# Altium schematic sheet ESP+Power Amplifier.SchDoc
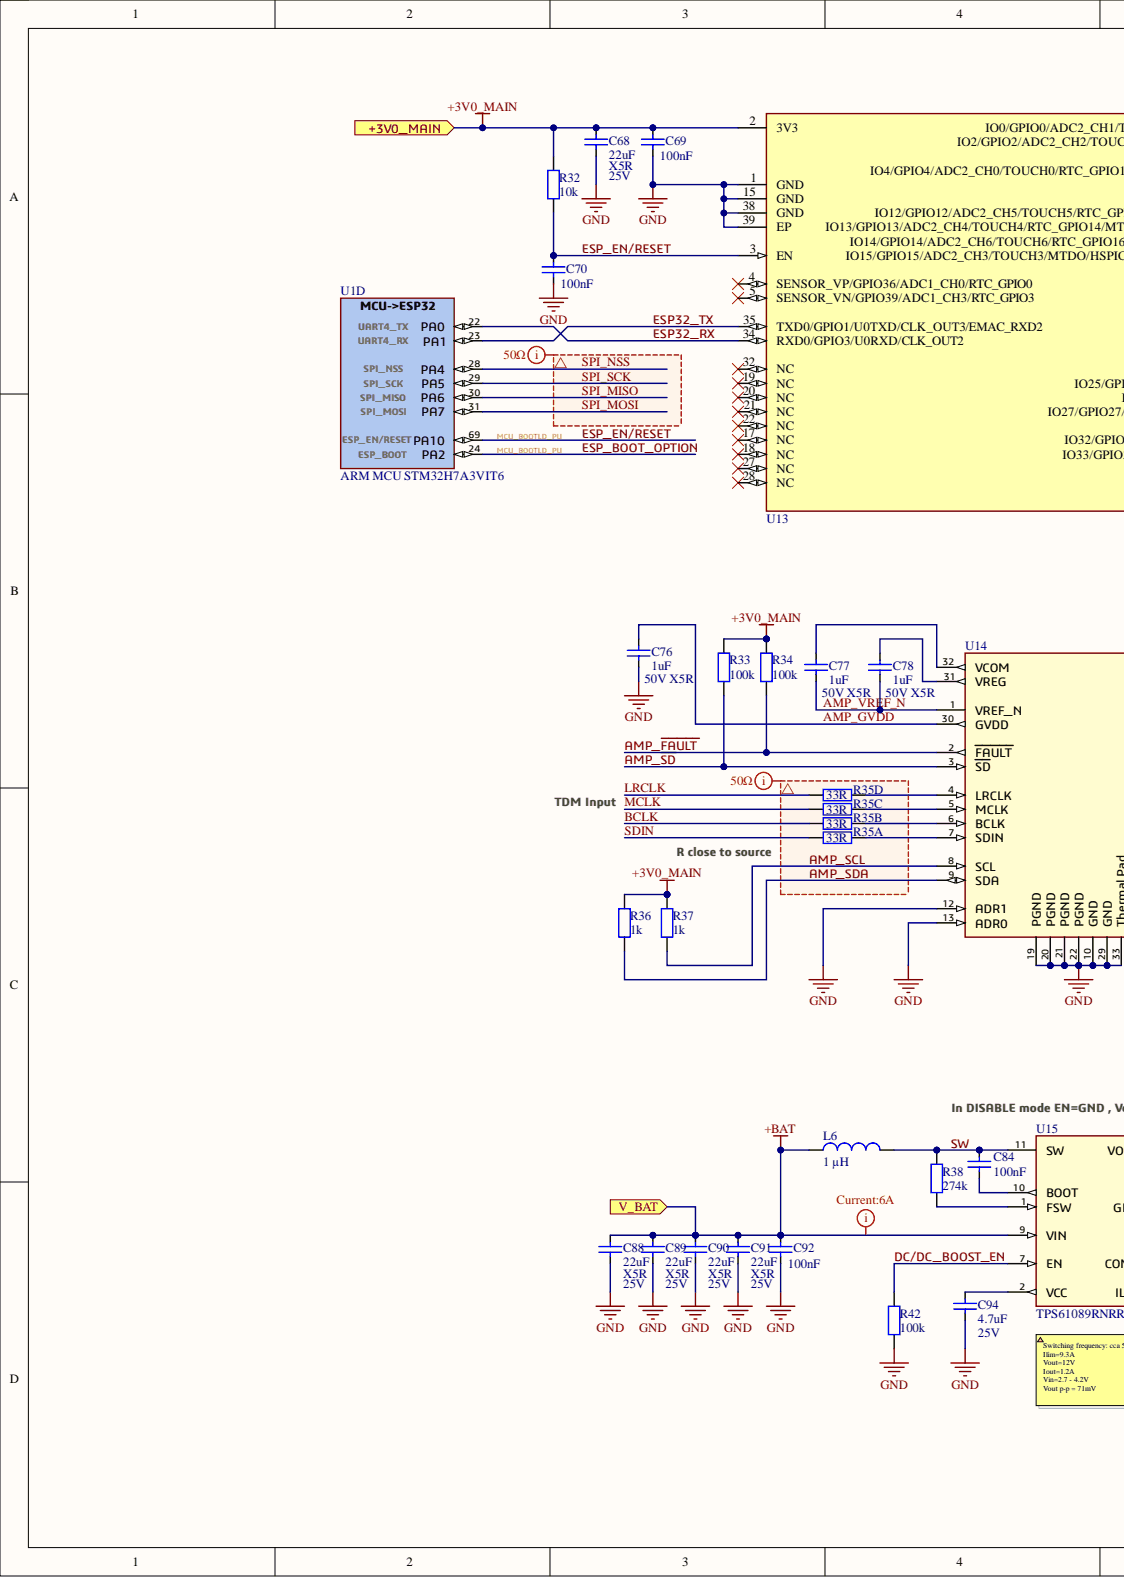
[diagram p0: ESP+Power Amplifier.SchDoc, left]
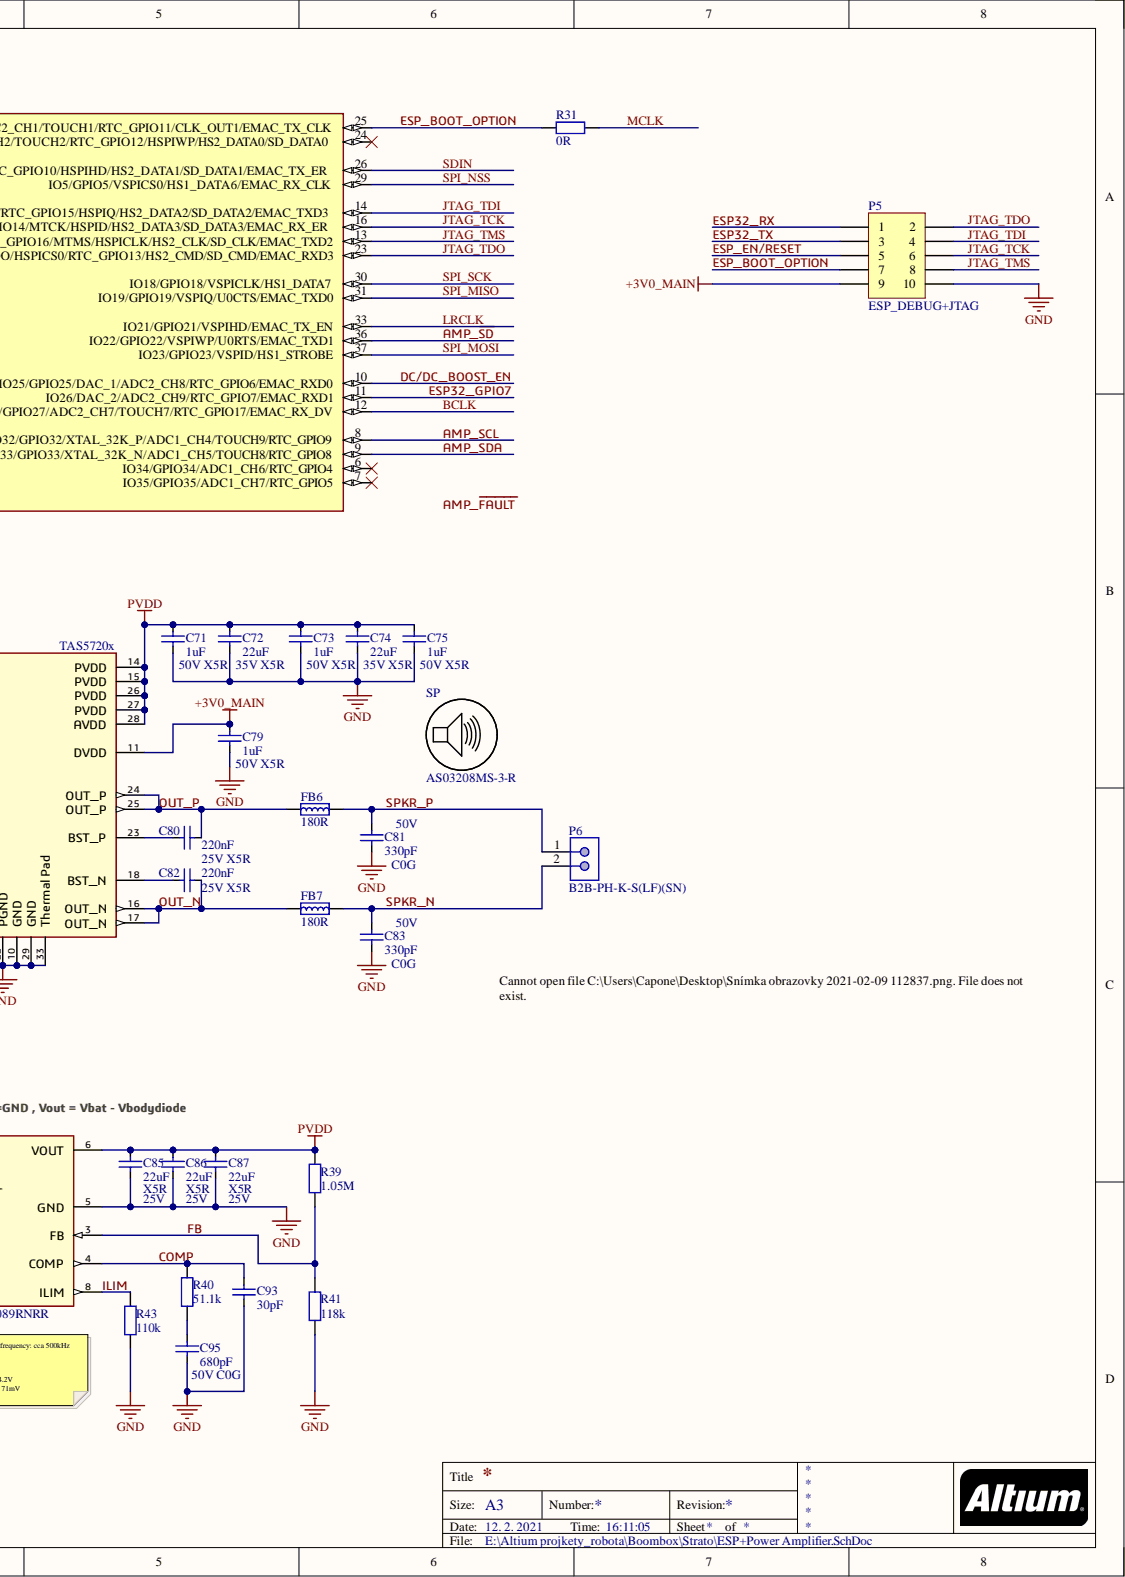
[diagram p1: ESP+Power Amplifier.SchDoc, right]

## Parts
C68  22uF  Dielectric: X5R  Voltage: 25V  [CAPACITOR_0805_(2012)]
C69  100nF  [CAPACITOR_0402_(1005)]
C70  100nF  [CAPACITOR_0402_(1005)]
C71  1uF  Voltage Rating (DC): 50V  [CAPACITOR_0603_(1608)]
C72  22uF  Voltage Rating: 35V X5R  Voltage Rating (DC): 35V  [CAPACITOR_1206_(3216)]
C73  1uF  Dielectric: X5R  Voltage Rating: 50V X5R  Voltage Rating (DC): 50V  [CAPACITOR_0603_(1608)]
C74  22uF  Voltage Rating: 35V X5R  Voltage Rating (DC): 35V  [CAPACITOR_1206_(3216)]
C75  1uF  Dielectric: X5R  Voltage Rating: 50V X5R  Voltage Rating (DC): 50V  [CAPACITOR_0603_(1608)]
C76  1uF  Dielectric: X5R  Voltage Rating: 50V X5R  Voltage Rating (DC): 50V  [CAPACITOR_0603_(1608)]
C77  1uF  Voltage Rating (DC): 50V  [CAPACITOR_0603_(1608)]
C78  1uF  Dielectric: X5R  Voltage Rating: 50V X5R  Voltage Rating (DC): 50V  [CAPACITOR_0603_(1608)]
C79  1uF  Dielectric: X5R  Voltage Rating: 50V X5R  Voltage Rating (DC): 50V  [CAPACITOR_0603_(1608)]
C80  220nF  [CAPACITOR_0603_(1608)]
C81  330pF  Dielectric: C0G  Voltage Rating: 50V  [CAPACITOR_0603_(1608)]
C82  220nF  Voltage Rating: 25V X5R  [CAPACITOR_0603_(1608)]
C83  330pF  Dielectric: C0G  Voltage Rating: 50V  [CAPACITOR_0603_(1608)]
C84  100nF  [CAPACITOR_0402_(1005)]
C85  22uF  Dielectric: X5R  Voltage: 25V  [CAPACITOR_0805_(2012)]
C86  22uF  Dielectric: X5R  Voltage: 25V  [CAPACITOR_0805_(2012)]
C87  22uF  Dielectric: X5R  Voltage: 25V  [CAPACITOR_0805_(2012)]
C88  22uF  Dielectric: X5R  Voltage: 25V  [CAPACITOR_0805_(2012)]
C89  22uF  Dielectric: X5R  Voltage: 25V  [CAPACITOR_0805_(2012)]
C90  22uF  Dielectric: X5R  Voltage: 25V  [CAPACITOR_0805_(2012)]
C91  22uF  Dielectric: X5R  Voltage: 25V  [CAPACITOR_0805_(2012)]
C92  100nF  [CAPACITOR_0402_(1005)]
C93  30pF  [CAPACITOR_0603_(1608)]
C94  4.7uF  Voltage: 25V  [CAPACITOR_0603_(1608)]
C95  680pF  Dielectric: C0G  Voltage: 50V  Voltage Rating: 50V C0G  [CAPACITOR_0603_(1608)]
FB6  180R  [FERRITE BEAD_0806 (2016 metric)]
FB7  180R  [FERRITE BEAD_0806 (2016 metric)]
L6  1 µH  [Power Inductor_073x066 (SMD)]
P5  ESP_DEBUG+JTAG  [FTE-105-XX-X-DV]  (no BOM)
P6  B2B-PH-K-S(LF)(SN)  [JST-B2B-PH-K-S]
R31  0R  [RESISTOR_0603_(1608)]
R32  10k  [RESISTOR_0603_(1608)]
R33  100k  [RESISTOR_0603_(1608)]
R34  100k  [RESISTOR_0603_(1608)]
R35  33R  [RESISTOR_NETWORK_4x_0603_(1608)]  (parts A,B,C,D)
R36  1k  [RESISTOR_0603_(1608)]
R37  1k  [RESISTOR_0603_(1608)]
R38  274k  [RESISTOR_0603_(1608)]
R39  1.05M  [RESISTOR_0603_(1608)]
R40  51.1k  [RESISTOR_0603_(1608)]
R41  118k  [RESISTOR_0603_(1608)]
R42  100k  [RESISTOR_0603_(1608)]
R43  110k  [RESISTOR_0603_(1608)]
SP  AS03208MS-3-R  [Speaker AS03208MS-3-R]
U1  ARM MCU STM32H7A3VIT6  Manufacturer Part Number: STM32H7A3VIT6  [STM-LQFP100_N - Edited]  (part D)
U13  ESP32-WROVER-E 64Mbit PSRAM, 16 MB SPI Flash  [ESP32-WROVER-E]  (value not drawn)
U14  TAS5720x  [VQFN32 4 x 4, 0.4 mm p]
U15  TPS61089RNRR  [VQFN_HR RNR0011A]

## Ports
+3V0_MAIN, V_BAT

## Nets
GND: C68.1 C69.2 C70.2 C71.2 C72.2 C73.2 C74.2 C75.2 C76.2 C79.2 C81.1 C83.1 C85.1 C86.1 C87.1 C88.1 C89.1 C90.1 C91.1 C92.2 C93.1 C94.2 C95.1 P5.10 R41.1 R42.1 R43.1 U13.1(GND) U13.15(GND) U13.38(GND) U13.39(EP) U14.10(GND) U14.12(ADR1) U14.13(ADR0) U14.19(PGND) U14.20(PGND) U14.21(PGND) U14.22(PGND) U14.29(GND) U14.33(Thermal_Pad) U15.5(GND)
PVDD: C71.1 C72.1 C73.1 C74.1 C75.1 C85.2 C86.2 C87.2 R39.2 U14.14(PVDD) U14.15(PVDD) U14.26(PVDD) U14.27(PVDD) U14.28(AVDD) U15.6(VOUT)
+3V0_MAIN: C68.2 C69.1 C79.1 P5.9 R32.1 R33.2 R34.2 R36.2 R37.2 U13.2(3V3) U14.11(DVDD) ; port +3V0_MAIN
+BAT: C88.2 C89.2 C90.2 C91.2 C92.1 L6.1 U15.9(VIN) ; port V_BAT
AMP_~{FAULT}: R34.1 U14.2(FAULT)
AMP_GVDD: C76.1 U14.30(GVDD)
AMP_~{SD}: R33.1 U13.36(IO22/GPIO22/VSPIWP/U0RTS/EMAC_TXD1) U14.3(SD)
AMP_SCL: R37.1 U13.8(IO32/GPIO32/XTAL_32K_P/ADC1_CH4/TOUCH9/RTC_GPIO9) U14.8(SCL)
AMP_SDA: R36.1 U13.9(IO33/GPIO33/XTAL_32K_N/ADC1_CH5/TOUCH8/RTC_GPIO8) U14.9(SDA)
AMP_VREF_N: C77.2 C78.2 U14.1(VREF_N)
BCLK: R35.4 U13.12(IO27/GPIO27/ADC2_CH7/TOUCH7/RTC_GPIO17/EMAC_RX_DV)
COMP: C93.2 R40.2 U15.4(COMP)
DC/DC_BOOST_EN: R42.2 U13.10(IO25/GPIO25/DAC_1/ADC2_CH8/RTC_GPIO6/EMAC_RXD0) U15.7(EN)
ESP32_GPIO7: U13.11(IO26/DAC_2/ADC2_CH9/RTC_GPIO7/EMAC_RXD1)
ESP32_RX: P5.1 U1.22(PA0) U13.34(RXD0/GPIO3/U0RXD/CLK_OUT2)
ESP32_TX: P5.3 U1.23(PA1) U13.35(TXD0/GPIO1/U0TXD/CLK_OUT3/EMAC_RXD2)
ESP_BOOT_OPTION: P5.7 R31.2 U1.24(PA2) U13.25(IO0/GPIO0/ADC2_CH1/TOUCH1/RTC_GPIO11/CLK_OUT1/EMAC_TX_CLK)
ESP_EN/RESET: C70.1 P5.5 R32.2 U1.69(PA10) U13.3(EN)
FB: R39.1 R41.2 U15.3(FB)
ILIM: R43.2 U15.8(ILIM)
JTAG_TCK: P5.6 U13.16(IO13/GPIO13/ADC2_CH4/TOUCH4/RTC_GPIO14/MTCK/HSPID/HS2_DATA3/SD_DATA3/EMAC_RX_ER)
JTAG_TDI: P5.4 U13.14(IO12/GPIO12/ADC2_CH5/TOUCH5/RTC_GPIO15/HSPIQ/HS2_DATA2/SD_DATA2/EMAC_TXD3)
JTAG_TDO: P5.2 U13.23(IO15/GPIO15/ADC2_CH3/TOUCH3/MTDO/HSPICS0/RTC_GPIO13/HS2_CMD/SD_CMD/EMAC_RXD3)
JTAG_TMS: P5.8 U13.13(IO14/GPIO14/ADC2_CH6/TOUCH6/RTC_GPIO16/MTMS/HSPICLK/HS2_CLK/SD_CLK/EMAC_TXD2)
LRCLK: R35.8 U13.33(IO21/GPIO21/VSPIHD/EMAC_TX_EN)
MCLK: R31.1 R35.6
OUT_N: C82.2 FB7.1 U14.16(OUT_N) U14.17(OUT_N)
OUT_P: C80.2 FB6.1 U14.24(OUT_P) U14.25(OUT_P)
SDIN: R35.2 U13.26(IO4/GPIO4/ADC2_CH0/TOUCH0/RTC_GPIO10/HSPIHD/HS2_DATA1/SD_DATA1/EMAC_TX_ER)
SPI_MISO: U1.30(PA6) U13.31(IO19/GPIO19/VSPIQ/U0CTS/EMAC_TXD0)
SPI_MOSI: U1.31(PA7) U13.37(IO23/GPIO23/VSPID/HS1_STROBE)
SPI_NSS: U1.28(PA4) U13.29(IO5/GPIO5/VSPICS0/HS1_DATA6/EMAC_RX_CLK)
SPI_SCK: U1.29(PA5) U13.30(IO18/GPIO18/VSPICLK/HS1_DATA7)
SPKR_N: C83.2 FB7.2 P6.2
SPKR_P: C81.2 FB6.2 P6.1
SW: C84.2 L6.2 R38.2 U15.11(SW)
NetC77_1: C77.1 U14.32(VCOM)
NetC78_1: C78.1 U14.31(VREG)
NetC80_1: C80.1 U14.23(BST_P)
NetC82_1: C82.1 U14.18(BST_N)
NetC84_1: C84.1 U15.10(BOOT)
NetC94_1: C94.1 U15.2(VCC)
NetC95_2: C95.2 R40.1
NetR35_1: R35.1 U14.7(SDIN)
NetR35_3: R35.3 U14.6(BCLK)
NetR35_5: R35.5 U14.5(MCLK)
NetR35_7: R35.7 U14.4(LRCLK)
NetR38_1: R38.1 U15.1(FSW)

Not connected: U13 4(SENSOR_VP/GPIO36/ADC1_CH0/RTC_GPIO0) 5(SENSOR_VN/GPIO39/ADC1_CH3/RTC_GPIO3) 6(IO34/GPIO34/ADC1_CH6/RTC_GPIO4) 7(IO35/GPIO35/ADC1_CH7/RTC_GPIO5) 17(NC) 18(NC) 19(NC) 20(NC) 21(NC) 22(NC) 24(IO2/GPIO2/ADC2_CH2/TOUCH2/RTC_GPIO12/HSPIWP/HS2_DATA0/SD_DATA0) 27(NC) 28(NC) 32(NC)

## Library data of the parts
C68 C85-C91 = CAPACITOR: Manufacturer: Murata; Manufacturer Part Number 1: GRM21BR61E226ME44L; Material: Ceramic; Tolerance: 20%; Capacitance: 22uF; Case Code (Imperial): 0805; Case Code (Metric): 2012; Case/Package: 0805; Component Kind: Standard; ComponentLink1Description: https://datasheet.ciiva.com/22787/1747471-22787265.pdf?src-supplier=Farnell; ComponentLink1URL: https://datasheet.ciiva.com/22787/1747471-22787265.pdf?src-supplier=Farnell; ComponentLink2Description: https://datasheet.ciiva.com/7719/1747471-7719095.pdf?src-supplier=Element14; ComponentLink2URL: https://datasheet.ciiva.com/7719/1747471-7719095.pdf?src-supplier=Element14; ComponentLink3Description: https://datasheet.ciiva.com/28584/1747471-28584199.pdf?src-supplier=Element14; ComponentLink3URL: https://datasheet.ciiva.com/28584/1747471-28584199.pdf?src-supplier=Element14; Composition: Ceramic; Depth: 1.25mm; Description: CAPACITOR; Height: 1.25mm; Lead Free: Lead Free; Length: 2mm; Max Operating Temperature: 85°C; Min Operating Temperature: -55°C; Mount: Surface Mount; Package Quantity: 3000; Packaging: Tape and Reel; Pricing 1: 5=0,42800, 50=0,25100, 250=0,20300, 500=0,15000, 1500=0,12800 (EUR); REACH SVHC: No SVHC; RoHS: Yes; Stock 1: 70400; Supplier 1: Farnell; Supplier Part Number 1: 1907510; Termination: SMD/SMT; Thickness: 0.057inch; Voltage Rating: 25V; Voltage Rating (DC): 25V; Weight: 0.000335oz; Width: 1.25mm; Library Name: RLCFB.SchLib
C69 C70 C84 C92 C93 = CAPACITOR: ESR: 0.28R; Voltage Rating: 10V; Component Kind: Standard; Description: CAPACITOR; Library Name: RLCFB.SchLib
  C69 C70 C84 C92: Capacitance: 100nF
  C93: Capacitance: 30pF
C71 C77 = CAPACITOR: Manufacturer: Taiyo Yuden; Manufacturer Part Number 1: UMK107BJ105KA-T; Dielectric: X5R; ESR: 0.28R; Tolerance: 10%; Voltage Rating: 50V X5R; Capacitance: 1uF; Case Code (Imperial): 0603; Case Code (Metric): 1608; Case/Package: 0603; Component Kind: Standard; ComponentLink1Description: https://datasheet.ciiva.com/5744/1697715-5744913.pdf?src-supplier=Farnell; ComponentLink1URL: https://datasheet.ciiva.com/5744/1697715-5744913.pdf?src-supplier=Farnell; ComponentLink2Description: https://datasheet.ciiva.com/11601/umk107bj105ka-t-ss-11601811.pdf?src-supplier=Avnet; ComponentLink2URL: https://datasheet.ciiva.com/11601/umk107bj105ka-t-ss-11601811.pdf?src-supplier=Avnet; ComponentLink3Description: https://datasheet.ciiva.com/15922/336umk107bj105ka-t-15922611.pdf?src-supplier=Onlinecomponents.com; ComponentLink3URL: https://datasheet.ciiva.com/15922/336umk107bj105ka-t-15922611.pdf?src-supplier=Onlinecomponents.com; ComponentLink4Description: https://datasheet.ciiva.com/134/umk107bj105ka-t-ss-134740.pdf?src-supplier=Digi-Key; ComponentLink4URL: https://datasheet.ciiva.com/134/umk107bj105ka-t-ss-134740.pdf?src-supplier=Digi-Key; ComponentLink5Description: https://datasheet.ciiva.com/30199/43umk107bj105ka-t-30199791.pdf?src-supplier=Onlinecomponents.com; ComponentLink5URL: https://datasheet.ciiva.com/30199/43umk107bj105ka-t-30199791.pdf?src-supplier=Onlinecomponents.com; ComponentLink6Description: https://datasheet.ciiva.com/22694/1697715-22694278.pdf?src-supplier=Element14; ComponentLink6URL: https://datasheet.ciiva.com/22694/1697715-22694278.pdf?src-supplier=Element14; ComponentLink7Description: https://datasheet.ciiva.com/7975/1697715-7975608.pdf?src-supplier=Element14; ComponentLink7URL: https://datasheet.ciiva.com/7975/1697715-7975608.pdf?src-supplier=Element14; ComponentLink8Description: https://datasheet.ciiva.com/7975/mlcc-all-e-7975898.pdf?src-supplier=Element14; ComponentLink8URL: https://datasheet.ciiva.com/7975/mlcc-all-e-7975898.pdf?src-supplier=Element14; ComponentLink9Description: https://datasheet.ciiva.com/5427/mlcc-all-e-541559-5427036.pdf?src-supplier=Mouser; ComponentLink9URL: https://datasheet.ciiva.com/5427/mlcc-all-e-541559-5427036.pdf?src-supplier=Mouser; Contact Plating: Nickel; Depth: 0.8mm; Description: CAPACITOR; Halogen Free: Halogen Free; Height: 0.8mm; Length: 1.6mm; Max Operating Temperature: 85°C; Min Operating Temperature: -55°C; Mount: Surface Mount; Number of Pins: 2; Package Quantity: 1; Packaging: Tape and Reel; Pricing 1: 10=0,14300, 100=0,06560, 500=0,04260, 1000=0,03940, 2000=0,03750, 4000=0,02830, 20000=0,02630 (EUR); Radiation Hardening: No; REACH SVHC: Unknown; RoHS: Yes; Stock 1: 372983; Supplier 1: Farnell; Supplier Part Number 1: 2112858; Termination: SMD/SMT; Thickness: 0.035inch; Width: 0.031inch; Library Name: RLCFB.SchLib
C72 C74 = CAPACITOR: Manufacturer: TDK; Manufacturer Part Number 1: C3216JB1V226M160AC; Dielectric Material: Ceramic; ESR: 0.28R; Tolerance: 20%; Capacitance: 22uF; Case Code (Imperial): 1206; Case Code (Metric): 3216; Case/Package: 1206; Component Kind: Standard; ComponentLink1Description: http://www.mouser.com/ds/2/400/lcc_commercial_general_en-837201.pdf?src-supplier=Mouser; ComponentLink1URL: http://www.mouser.com/ds/2/400/lcc_commercial_general_en-837201.pdf?src-supplier=Mouser; ComponentLink2Description: https://product.tdk.com/info/en/catalog/spec/mlccspec_commercial_general_midvoltage_en.pdf?src-supplier=Digi-Key; ComponentLink2URL: https://product.tdk.com/info/en/catalog/spec/mlccspec_commercial_general_midvoltage_en.pdf?src-supplier=Digi-Key; ComponentLink3Description: http://product.tdk.com/en/catalog/datasheets/mlcc_commercial_general_en.pdf?src-supplier=Avnet; ComponentLink3URL: http://product.tdk.com/en/catalog/datasheets/mlcc_commercial_general_en.pdf?src-supplier=Avnet; Composition: Ceramic; Description: CAPACITOR; Height: 1.6mm; Lead Free: Lead Free; Length: 0.126inch; Max Operating Temperature: 85°C; Min Operating Temperature: -25°C; Mount: Surface Mount; Package Quantity: 2000; Packaging: Tape and Reel; Pricing 1: 1=1,12000, 10=0,84700, 50=0,84700, 100=0,59600, 1000=0,45200, 10000=0,28700 (USD); RoHS: Yes; Stock 1: 128007; Supplier 1: Mouser; Supplier Part Number 1: 810-C3216JB1V226M16C; Termination: SMD/SMT; Thickness: 0.069inch; Width: 0.063inch; Library Name: RLCFB.SchLib
C73 C75 C76 C78 C79 = CAPACITOR: Manufacturer: Taiyo Yuden; Manufacturer Part Number 1: UMK107BJ105KA-T; ESR: 0.28R; Tolerance: 10%; Capacitance: 1uF; Case Code (Imperial): 0603; Case Code (Metric): 1608; Case/Package: 0603; Component Kind: Standard; ComponentLink1Description: https://datasheet.ciiva.com/5744/1697715-5744913.pdf?src-supplier=Farnell; ComponentLink1URL: https://datasheet.ciiva.com/5744/1697715-5744913.pdf?src-supplier=Farnell; ComponentLink2Description: https://datasheet.ciiva.com/11601/umk107bj105ka-t-ss-11601811.pdf?src-supplier=Avnet; ComponentLink2URL: https://datasheet.ciiva.com/11601/umk107bj105ka-t-ss-11601811.pdf?src-supplier=Avnet; ComponentLink3Description: https://datasheet.ciiva.com/15922/336umk107bj105ka-t-15922611.pdf?src-supplier=Onlinecomponents.com; ComponentLink3URL: https://datasheet.ciiva.com/15922/336umk107bj105ka-t-15922611.pdf?src-supplier=Onlinecomponents.com; ComponentLink4Description: https://datasheet.ciiva.com/134/umk107bj105ka-t-ss-134740.pdf?src-supplier=Digi-Key; ComponentLink4URL: https://datasheet.ciiva.com/134/umk107bj105ka-t-ss-134740.pdf?src-supplier=Digi-Key; ComponentLink5Description: https://datasheet.ciiva.com/30199/43umk107bj105ka-t-30199791.pdf?src-supplier=Onlinecomponents.com; ComponentLink5URL: https://datasheet.ciiva.com/30199/43umk107bj105ka-t-30199791.pdf?src-supplier=Onlinecomponents.com; ComponentLink6Description: https://datasheet.ciiva.com/22694/1697715-22694278.pdf?src-supplier=Element14; ComponentLink6URL: https://datasheet.ciiva.com/22694/1697715-22694278.pdf?src-supplier=Element14; ComponentLink7Description: https://datasheet.ciiva.com/7975/1697715-7975608.pdf?src-supplier=Element14; ComponentLink7URL: https://datasheet.ciiva.com/7975/1697715-7975608.pdf?src-supplier=Element14; ComponentLink8Description: https://datasheet.ciiva.com/7975/mlcc-all-e-7975898.pdf?src-supplier=Element14; ComponentLink8URL: https://datasheet.ciiva.com/7975/mlcc-all-e-7975898.pdf?src-supplier=Element14; ComponentLink9Description: https://datasheet.ciiva.com/5427/mlcc-all-e-541559-5427036.pdf?src-supplier=Mouser; ComponentLink9URL: https://datasheet.ciiva.com/5427/mlcc-all-e-541559-5427036.pdf?src-supplier=Mouser; Contact Plating: Nickel; Depth: 0.8mm; Description: CAPACITOR; Halogen Free: Halogen Free; Height: 0.8mm; Length: 1.6mm; Max Operating Temperature: 85°C; Min Operating Temperature: -55°C; Mount: Surface Mount; Number of Pins: 2; Package Quantity: 1; Packaging: Tape and Reel; Pricing 1: 10=0,14300, 100=0,06560, 500=0,04260, 1000=0,03940, 2000=0,03750, 4000=0,02830, 20000=0,02630 (EUR); Radiation Hardening: No; REACH SVHC: Unknown; RoHS: Yes; Stock 1: 372983; Supplier 1: Farnell; Supplier Part Number 1: 2112858; Termination: SMD/SMT; Thickness: 0.035inch; Width: 0.031inch; Library Name: RLCFB.SchLib
C80 = CAPACITOR: ESR: 0.28R; Voltage Rating: 25V X5R; Capacitance: 220nF; Component Kind: Standard; Description: CAPACITOR; Library Name: RLCFB.SchLib
C81 C83 = CAPACITOR: Manufacturer: Kyocera AVX; Manufacturer Part Number 1: 06035A331FAT2A; Tolerance: 1%; Capacitance: 330pF; Case Code (Imperial): 0603; Case Code (Metric): 1608; Case/Package: 0603; Component Kind: Standard; ComponentLink1Description: https://datasheet.ciiva.com/152/ccog-152848.pdf?src-supplier=Digi-Key; ComponentLink1URL: https://datasheet.ciiva.com/152/ccog-152848.pdf?src-supplier=Digi-Key; ComponentLink2Description: https://datasheet.ciiva.com/152/ccog-152849.pdf?src-supplier=Digi-Key; ComponentLink2URL: https://datasheet.ciiva.com/152/ccog-152849.pdf?src-supplier=Digi-Key; ComponentLink3Description: https://datasheet.ciiva.com/152/ccog-152850.pdf?src-supplier=Digi-Key; ComponentLink3URL: https://datasheet.ciiva.com/152/ccog-152850.pdf?src-supplier=Digi-Key; ComponentLink4Description: https://datasheet.ciiva.com/22677/1796416-22677029.pdf?src-supplier=Element14; ComponentLink4URL: https://datasheet.ciiva.com/22677/1796416-22677029.pdf?src-supplier=Element14; ComponentLink5Description: https://datasheet.ciiva.com/5415/ccog-243403-5415239.pdf?src-supplier=Mouser; ComponentLink5URL: https://datasheet.ciiva.com/5415/ccog-243403-5415239.pdf?src-supplier=Mouser; ComponentLink6Description: https://datasheet.ciiva.com/17491/ccog-17491576.pdf?src-supplier=Avnet+Europe; ComponentLink6URL: https://datasheet.ciiva.com/17491/ccog-17491576.pdf?src-supplier=Avnet+Europe; Contact Plating: Tin,Matte; Depth: 0.81mm; Description: CAPACITOR; Height: 0.63mm; Lead Free: Lead Free; Length: 1.6mm; Max Operating Temperature: 125°C; Min Operating Temperature: -55°C; Mount: Surface Mount; Number of Pins: 2; Package Quantity: 1; Packaging: Tape and Reel; Pricing 1: 10=0,38200, 100=0,17000, 500=0,12600, 1000=0,10600, 2000=0,09380, 4000=0,07570, 20000=0,06650 (EUR); Radiation Hardening: No; REACH SVHC: No SVHC; RoHS: Yes; Stock 1: 36265; Supplier 1: Farnell; Supplier Part Number 1: 2332616; Termination: SMD/SMT; Thickness: 0.035inch; Voltage Rating (DC): 50V; Width: 0.032inch; Library Name: RLCFB.SchLib
C82 = CAPACITOR: ESR: 0.28R; Capacitance: 220nF; Component Kind: Standard; Description: CAPACITOR; Library Name: RLCFB.SchLib
C94 = CAPACITOR: Manufacturer: Murata; Manufacturer Part Number 1: GRM188R61E475KE11D; Dielectric: X5R; ESR: 0.28R; Material: Ceramic; Tolerance: 10%; Capacitance: 4.7uF; Case Code (Imperial): 0603; Case Code (Metric): 1608; Case/Package: 0603; Component Kind: Standard; ComponentLink1Description: https://datasheet.ciiva.com/46444/1769893-46444982.pdf?src-supplier=Element14; ComponentLink1URL: https://datasheet.ciiva.com/46444/1769893-46444982.pdf?src-supplier=Element14; ComponentLink2Description: https://datasheet.ciiva.com/46444/1769893-46444983.pdf?src-supplier=Element14; ComponentLink2URL: https://datasheet.ciiva.com/46444/1769893-46444983.pdf?src-supplier=Element14; ComponentLink3Description: https://datasheet.ciiva.com/14891/partnumbering-e-01-14891102.pdf?src-supplier=Digi-Key; ComponentLink3URL: https://datasheet.ciiva.com/14891/partnumbering-e-01-14891102.pdf?src-supplier=Digi-Key; ComponentLink4Description: https://datasheet.ciiva.com/14891/partnumbering-e-01-14891103.pdf?src-supplier=Digi-Key; ComponentLink4URL: https://datasheet.ciiva.com/14891/partnumbering-e-01-14891103.pdf?src-supplier=Digi-Key; Depth: 0.8mm; Description: CAPACITOR; Height: 0.8mm; Lead Free: Lead Free; Length: 1.6mm; Max Operating Temperature: 85°C; Min Operating Temperature: -55°C; Mount: Surface Mount; Package Quantity: 4000; Packaging: Cut Tape; Pricing 1: 10=0,35700, 100=100,00000, 500=0,15900, 1000=0,12800, 2000=0,10200 (CHF); REACH SVHC: No SVHC; RoHS: Yes; Stock 1: 95119; Supplier 1: Farnell; Supplier Part Number 1: 2426959; Thickness: 0.031inch; Voltage Rating: 25V; Voltage Rating (DC): 25V; Width: 0.031inch; Library Name: RLCFB.SchLib
C95 = CAPACITOR: Manufacturer: KEMET; Manufacturer Part Number 1: C0603C681J5GACTU; Dielectric Material: Ceramic; Dissipation Factor: 0.1%; ESR: 0.28R; Temperature Coefficient: 30ppm/°C; Tolerance: 5%; Capacitance: 680pF; Case Code (Imperial): 0603; Case Code (Metric): 1608; Case/Package: 0603; Component Kind: Standard; ComponentLink1Description: https://datasheet.ciiva.com/144/docfinderpartnumber-c0603c681j5gactu-144808.pdf?src-supplier=Digi-Key; ComponentLink1URL: https://datasheet.ciiva.com/144/docfinderpartnumber-c0603c681j5gactu-144808.pdf?src-supplier=Digi-Key; ComponentLink2Description: https://datasheet.ciiva.com/28535/1372242-28535863.pdf?src-supplier=Element14; ComponentLink2URL: https://datasheet.ciiva.com/28535/1372242-28535863.pdf?src-supplier=Element14; ComponentLink3Description: https://datasheet.ciiva.com/7823/40889-7823833.pdf?src-supplier=Element14; ComponentLink3URL: https://datasheet.ciiva.com/7823/40889-7823833.pdf?src-supplier=Element14; ComponentLink4Description: https://datasheet.ciiva.com/7823/40889-7823834.pdf?src-supplier=Element14; ComponentLink4URL: https://datasheet.ciiva.com/7823/40889-7823834.pdf?src-supplier=Element14; ComponentLink5Description: https://datasheet.ciiva.com/14600/70095383-14600026.pdf?src-supplier=Allied+Electronics; ComponentLink5URL: https://datasheet.ciiva.com/14600/70095383-14600026.pdf?src-supplier=Allied+Electronics; ComponentLink6Description: https://datasheet.ciiva.com/11634/kem-c1003-c0g-smd-11634445.pdf?src-supplier=Avnet; ComponentLink6URL: https://datasheet.ciiva.com/11634/kem-c1003-c0g-smd-11634445.pdf?src-supplier=Avnet; Contact Plating: Tin,Matte; Depth: 0.8mm; Description: CAPACITOR; Height: 0.8mm; Lead Free: Lead Free; Lead Pitch: 0.7mm; Length: 1.6mm; Max Operating Temperature: 125°C; Min Operating Temperature: -55°C; Mount: Surface Mount,PCB; Number of Pins: 2; Package Quantity: 4000; Packaging: Tape and Reel; Pricing 1: 10=0,05930, 100=0,04080, 500=0,02740, 1000=0,02050, 2000=0,01880 (EUR); Radiation Hardening: No; REACH SVHC: No SVHC; RoHS: Yes; Stock 1: 20174; Supplier 1: Farnell; Supplier Part Number 1: 1414648; Termination: SMD/SMT; Thickness: 0.034inch; Voltage Rating (DC): 50V; Width: 0.8mm; Library Name: RLCFB.SchLib
FB6 FB7 = FERRITE_BEAD: Manufacturer: Murata; Manufacturer Part Number 1: NFZ2MSM181SN10L; DC Resistance (DCR): 25mR; DC Resistance Max: 100R; Max Current Rating: 3.4A; Number of Lines: 1; Case Code (Imperial): 0806; Case Code (Metric): 2016; Case/Package: 0806; Component Kind: Standard; ComponentLink1Description: https://www.murata.com/en-us/products/productdata/8796736815134/ENFJ0002.pdf?src-supplier=Digi-Key; ComponentLink1URL: https://www.murata.com/en-us/products/productdata/8796736815134/ENFJ0002.pdf?src-supplier=Digi-Key; Description: FERRITE_BEAD; Impedance@100MHz: 180R; Max Operating Temperature: 85°C; Min Operating Temperature: -40°C; Mount: Surface Mount; Packaging: Tape and Reel; Pricing 1: 1=0,37000, 10=0,23000, 100=0,20000, 250=0,17700, 500=0,16000, 1000=0,13600 (USD); RoHS: No; Stock 1: 8719; Supplier 1: Mouser; Supplier Part Number 1: 81-NFZ2MSM181SN10L; Library Name: RLCFB.SchLib
L6 = INDUCTOR: INDUCTOR_High_Frequency; Manufacturer: Bourns; Manufacturer Part Number: SRP7028A-1R0M; Core Material: Ferrite; Current Rating: 11A; DC Resistance (DCR): 10mR; DC Resistance Max: 0,01ohm; Inductance Tolerance: 20%; Q Factor: 20; Saturation Current: 22A; Self Resonant Frequency: 58MHz; Shielding: Shielded; Test Frequency: 100kHz; Case/Package: Nonstandard; Component Kind: Standard; ComponentLink1Description: https://datasheet.ciiva.com/46385/1828826-46385115.pdf?src-supplier=Element14; ComponentLink1URL: https://datasheet.ciiva.com/46385/1828826-46385115.pdf?src-supplier=Element14; ComponentLink2Description: https://datasheet.ciiva.com/48685/srp7028a-48685356.pdf?src-supplier=Avnet; ComponentLink2URL: https://datasheet.ciiva.com/48685/srp7028a-48685356.pdf?src-supplier=Avnet; ComponentLink3Description: https://datasheet.ciiva.com/48685/srp7028a-48685359.pdf?src-supplier=Avnet; ComponentLink3URL: https://datasheet.ciiva.com/48685/srp7028a-48685359.pdf?src-supplier=Avnet; Composition: Wirewound; Contact Plating: Tin; DC Current: 11A; DC Current Rating: 11A; Depth: 6.6mm; Height: 2.8mm; Height - Seated (Max): 0.118inch; Inductance: 1 µH; Length: 7.3mm; Max DC Current: 11A; Max Operating Temperature: 150°C; Military Standard: Not; Min Operating Temperature: -40°C; Mount: Surface Mount; Package Quantity: 1000; Packaging: Tape and Reel; Pricing 1: 1=1,47000, 50=0,85200, 100=0,75000, 250=0,69400, 500=0,64700, 1000=0,61500, 5000=0,58300 (EUR); Radiation Hardening: No; Ratings: AEC-Q200; REACH SVHC: No SVHC; RMS Current (Irms): 11A; RoHS: Yes; Stock 1: 1615; Supplier 1: Farnell; Supplier Part Number 1: 2434094; Termination: SMD/SMT; Tolerance: 20%; Width: 6.6mm; Library Name: RLCFB.SchLib
P5 = Header 5X2: CONN HEADER 10POS .8MM DUAL SMD; Manufacturer: Samtec; Manufacturer Part Number: FTE-105-01-G-DV; Gender: Male; Number of Contacts: 10; Pitch: 0.8mm; Row Spacing: 0.047inch; Category: Connectors; Color: Black; ComponentLink1Description: http://components.arrow.com/part/dataview/0323_01320508?src-supplier=Arrow+Europe; ComponentLink1URL: http://components.arrow.com/part/dataview/0323_01320508?src-supplier=Arrow+Europe; ComponentLink2Description: http://www.farnell.com/datasheets/1927686.pdf?src-supplier=Farnell; ComponentLink2URL: http://www.farnell.com/datasheets/1927686.pdf?src-supplier=Farnell; ComponentLink3Description: http://www.farnell.com/datasheets/1927686.pdf?src-supplier=Element14; ComponentLink3URL: http://www.farnell.com/datasheets/1927686.pdf?src-supplier=Element14; ComponentLink4Description: http://suddendocs.samtec.com/prints/fte-1xx-xx-x-dv-xx-a-footprint.pdf?src-supplier=Digi-Key; ComponentLink4URL: http://suddendocs.samtec.com/prints/fte-1xx-xx-x-dv-xx-a-footprint.pdf?src-supplier=Digi-Key; ComponentLink5Description: http://www.samtec.com/documents/webfiles/pdf/FTE_SM.PDF?src-supplier=Avnet; ComponentLink5URL: http://www.samtec.com/documents/webfiles/pdf/FTE_SM.PDF?src-supplier=Avnet; Contact Material: Bronze; Contact Mating Length: 0.075inch; Contact Plating: Gold; Depth: 3.05mm; Housing Color: Black; Housing Material: Polymer; Lead Free: Lead Free; Length: 4mm; Library Name: Miscellaneous Connectors.IntLib; Max Operating Temperature: 125°C; Min Operating Temperature: -55°C; Mount: Surface Mount; Number of Positions: 10; Number of Rows: 2; Orientation: Straight; Packaging: Bulk; Pricing 1: 1=2,14000, 10=1,74000, 100=1,55000, 250=1,45000, 500=1,25000, 1000=1,09000 (USD); REACH SVHC: No SVHC; RoHS: Yes; Signal Integrity: Connector; Stock 1: 262; Supplier 1: Mouser; Supplier Part Number 1: 200-FTE10501GDV; Termination: Solder
P6 = B2B-PH-K-S: Connector Header, PH Series, 2 Position, 2.00mm, Through Hole, Press-Fit, Solder, Natural; Manufacturer: JST; Manufacturer Part Number: B2BPHKSLFSN; Connector Type: Male Pin; Contact Resistance: 20mR; Current Rating: 2A; Gender: Male; Insulation Resistance: 1MR; Max Voltage Rating (AC): 100V; Number of Contacts: 2; Pitch: 2mm; Category: Connectors; Color: Natural; Component Kind: Standard; ComponentLink1Description: https://datasheet.ciiva.com/6747/80560-6747392.pdf?src-supplier=Newark; ComponentLink1URL: https://datasheet.ciiva.com/6747/80560-6747392.pdf?src-supplier=Newark; ComponentLink2Description: https://datasheet.ciiva.com/11746/0900766b8082f551-11746676.pdf?src-supplier=RSComponents; ComponentLink2URL: https://datasheet.ciiva.com/11746/0900766b8082f551-11746676.pdf?src-supplier=RSComponents; ComponentLink3Description: https://datasheet.ciiva.com/8052/80560-8052022.pdf?src-supplier=Element14; ComponentLink3URL: https://datasheet.ciiva.com/8052/80560-8052022.pdf?src-supplier=Element14; ComponentLink4Description: https://datasheet.ciiva.com/712/eph-712442.pdf?src-supplier=Digi-Key; ComponentLink4URL: https://datasheet.ciiva.com/712/eph-712442.pdf?src-supplier=Digi-Key; ComponentLink5Description: https://datasheet.ciiva.com/16422/b2b-ph-k-slfsn-16422715.pdf?src-supplier=Future+Electronics; ComponentLink5URL: https://datasheet.ciiva.com/16422/b2b-ph-k-slfsn-16422715.pdf?src-supplier=Future+Electronics; Contact Material: Brass,Tin; Contact Mating Length: 0.13inch; Contact Plating: Tin,Copper; Depth: 4.5mm; Fastening Type: Detent; Height: 9.4mm; Housing Color: White; Housing Material: Polyamide; Lead Free: Lead Free; Lead Pitch: 2mm; Length: 5.9mm; Library Name: BB1_SCH_Library.SchLib; Max Current Rating: 2A; Max Operating Temperature: 85°C; Max Voltage Rating (DC): 100V; Min Operating Temperature: -25°C; Mount: Through Hole; Number of Pins: 2; Number of Poles: 2; Number of Positions: 2; Number of Rows: 1; Orientation: Straight; Packaging: Bulk; Pricing 1: 100=0,080300, 1000=0,058100, 2500=0,054000, 5000=0,049900, 10000=0,048400, 20000=0,047400 (GBP); REACH SVHC: No SVHC; RoHS: Yes; Stock 1: 546079; Supplier 1: Farnell; Supplier Part Number 1: 9491856; Termination: Solder; Voltage Rating: 100V; Voltage Rating (AC): 100V; Width: 4.5mm; Working Voltage: 100V
R31-R34 R36-R43 = RESISTOR: Power Rating: 500mW; Component Kind: Standard; Description: RESISTOR; Library Name: RLCFB.SchLib
  R31: Resistance: 0R
  R32: Resistance: 10k
  R33 R34 R42: Resistance: 100k
  R36 R37: Resistance: 1k
  R38: Resistance: 274k
  R39: Resistance: 1.05M
  R40: Resistance: 51.1k
  R41: Resistance: 118k
  R43: Resistance: 110k
R35 = RESISTOR Network 4 Elements, Isolated, 0603, 33R: EXBV8V330JV -  Fixed Network Resistor, 33 ohm, EXBV Seies, 4 Elements, Isolated, 0603 [1608 Metric], 8 Pins; Manufacturer: Panasonic; Manufacturer Part Number 1: EXB-V8V330JV; Number of Contacts: 8; Number of Elements: 4; Power Dissipation: 63mW; Power Per Element: 62.5mW; Temperature Coefficient: 200ppm/°C; Tolerance: 5%; Voltage Rating: 50V; Voltage Rating (DC): 100V; Case Code (Imperial): 0603; Case Code (Metric): 1608; Case/Package: 0603; Circuit Type: Isolated; Component Kind: Standard; Contact Plating: Tin; Depth: 1.6mm; Height: 0.028inch; Lead Free: Lead Free; Length: 3.2mm; Library Name: Source.SchLib; Max Operating Temperature: 125°C; Military Standard: Not; Min Operating Temperature: -55°C; Mount: Surface Mount; Number of Pins: 8; Number of Resistors: 4; Number of Terminals: 8; Package Quantity: 1; Packaging: Tape and Reel; Pricing 1: 10=0,05970, 100=0,04480, 500=0,03590, 1000=0,03170, 2500=0,02440, 5000=0,02030, 25000=0,01610 (EUR); Radiation Hardening: No; REACH SVHC: No SVHC; Resistance: 33R; RoHS: Yes; Stock 1: 1324; Supplier 1: Farnell; Supplier Part Number 1: 2060153; Terminal Pitch: 0.8mm; Termination: Concave; Thickness: 600um; Width: 0.063inch
SP = Speaker_Mechanical: SPEAKER 8OHM 3W TOP PORT 85DB; Manufacturer: Projects Unlimited; Impedance: 8R; Max Power Dissipation: 4W; Shape: Square; Component Kind: Standard; ComponentLink1Description: http://www.puiaudio.com/pdf/AS03208MS-3-R.pdf?src-supplier=Digi-Key; ComponentLink1URL: http://www.puiaudio.com/pdf/AS03208MS-3-R.pdf?src-supplier=Digi-Key; Height: 17mm; Length: 31.7mm; Library Name: BB1_SCH_Library.SchLib; Manufacturer Part Number: AS03208MS-3-R; Power Rating: 3W; Pricing 1: 1=6,88000, 10=5,65000, 25=5,20000, 50=4,88000, 100=4,56000, 250=4,56000 (USD); RoHS: Yes; Stock 1: 625; Supplier 1: Mouser; Supplier Part Number 1: 665-AS03208MS-3-R; Width: 31.7mm
U1 = STM32H7A3VIT6_LQFP100: STM32H7A3VIT6 -  32-bit Arm® Cortex®-M7 280 MHz MCUs, up to 2-Mbyte Flash memory, 1.4Mbyte RAM, 46 com. and analog interfaces; Manufacturer: STMicroelectronics; Category: Microcontrollers; Component Kind: Standard; ComponentLink1Description: Datasheet; ComponentLink1URL: https://www.st.com/resource/en/datasheet/stm32h7a3vi.pdf; Library Name: BB1_SCH_Library.SchLib; RoHS: No; Supplier 1: Mouser; Supplier Part Number 1: 511-STM32H7A3VIT6
U13 = ESP32-WROVER-E: WiFi Modules (802.11) SMD Module ESP32-WROVER-E, ESP32-D0WD-V3, 3.3V 64Mbit PSRAM, 16 MB SPI flash, PCB Antenna; Manufacturer: Espressif Systems; Manufacturer Part Number: ESP32-WROVER-E(M213EH2864PH3Q0); Availability: Unavailable; Component Kind: Standard; Library Name: BB1_SCH_Library.SchLib; MF: Espressif Systems; MP: ESP32-WROVER; Package: Module Espressif Systems; Price: None; Pricing 1: 1=3,28000, 5=3,28000 (USD); RoHS: No; SnapEDA_Link: https://www.snapeda.com/parts/ESP32-WROVER/Espressif%20Systems/view-part/2293722/?ref=snap; Supplier 1: Mouser; Supplier Part Number 1: 356-ESP32WRVE22864PC
U14 = TAS5720L  I2S AMP: TAS5720x Digital Input Mono Class-D Audio Amplifier  With TDM Support Up To 8 Channels; Manufacturer: Texas Instruments; Manufacturer Part Number 1: TAS5720LRSMT; Max Output Power: 20W; Case/Package: VQFN; Component Kind: Standard; ComponentLink1Description: http://www.ti.com/lit/gpn/tas5720l?src-supplier=Mouser; ComponentLink1URL: http://www.ti.com/lit/gpn/tas5720l?src-supplier=Mouser; ComponentLink2Description: http://www.ti.com/lit/ds/symlink/tas5720l.pdf?src-supplier=Digi-Key; ComponentLink2URL: http://www.ti.com/lit/ds/symlink/tas5720l.pdf?src-supplier=Digi-Key; Lead Free: Lead Free; Library Name: BB1_SCH_Library.SchLib; Max Operating Temperature: 85°C; Min Operating Temperature: -25°C; Mount: Surface Mount; Packaging: Tape and Reel; Pricing 1: 1=4,07000, 10=3,66000, 100=2,99000, 250=2,81000, 500=2,55000, 1000=2,15000 (USD); RoHS: Yes; Stock 1: 421; Supplier 1: Mouser; Supplier Part Number 1: 595-TAS5720LRSMT
U15 = TPS61089RNRR: Switching Voltage Regulators; Manufacturer: Texas Instruments; Max Input Voltage: 12V; Max Output Voltage: 12.6V; Min Input Voltage: 2.7V; Min Output Voltage: 4.5V; Number of Outputs: 1; Output Configuration: Positive; Output Current: 7A; Output Type: Adjustable; Quiescent Current: 10uA; Switching Frequency: 2.2MHz; Synchronous Rectifier: Yes; Topology: Boost; Case/Package: VQFN; Component Kind: Standard; ComponentLink1Description: http://www.ti.com/lit/ds/symlink/tps61089.pdf?src-supplier=Digi-Key; ComponentLink1URL: http://www.ti.com/lit/ds/symlink/tps61089.pdf?src-supplier=Digi-Key; ComponentLink2Description: http://www.ti.com/general/docs/suppproductinfo.tsp?distId=10&amp;gotoUrl=http%3A%2F%2Fwww.ti.com%2Flit%2Fgpn%2Ftps61089&src-supplier=Digi-Key; ComponentLink2URL: http://www.ti.com/general/docs/suppproductinfo.tsp?distId=10&amp;gotoUrl=http%3A%2F%2Fwww.ti.com%2Flit%2Fgpn%2Ftps61089&src-supplier=Digi-Key; Lead Free: Lead Free; Library Name: BB1_SCH_Library.SchLib; Manufacturer Part Number 1: TPS61089RNRR; Max Operating Temperature: 85°C; Min Operating Temperature: -40°C; Mount: Surface Mount; Number of Pins: 11; Packaging: Tape and Reel; Pricing 1: 1=2,85000, 10=2,42000, 100=1,94000, 500=1,70000, 1000=1,41000, 3000=1,31000, 6000=1,26000, 9000=1,21000 (USD); RoHS: Yes; Stock 1: 7016; Supplier 1: Mouser; Supplier Part Number 1: 595-TPS61089RNRR
